# Altium schematic sheet SIM808.SchDoc
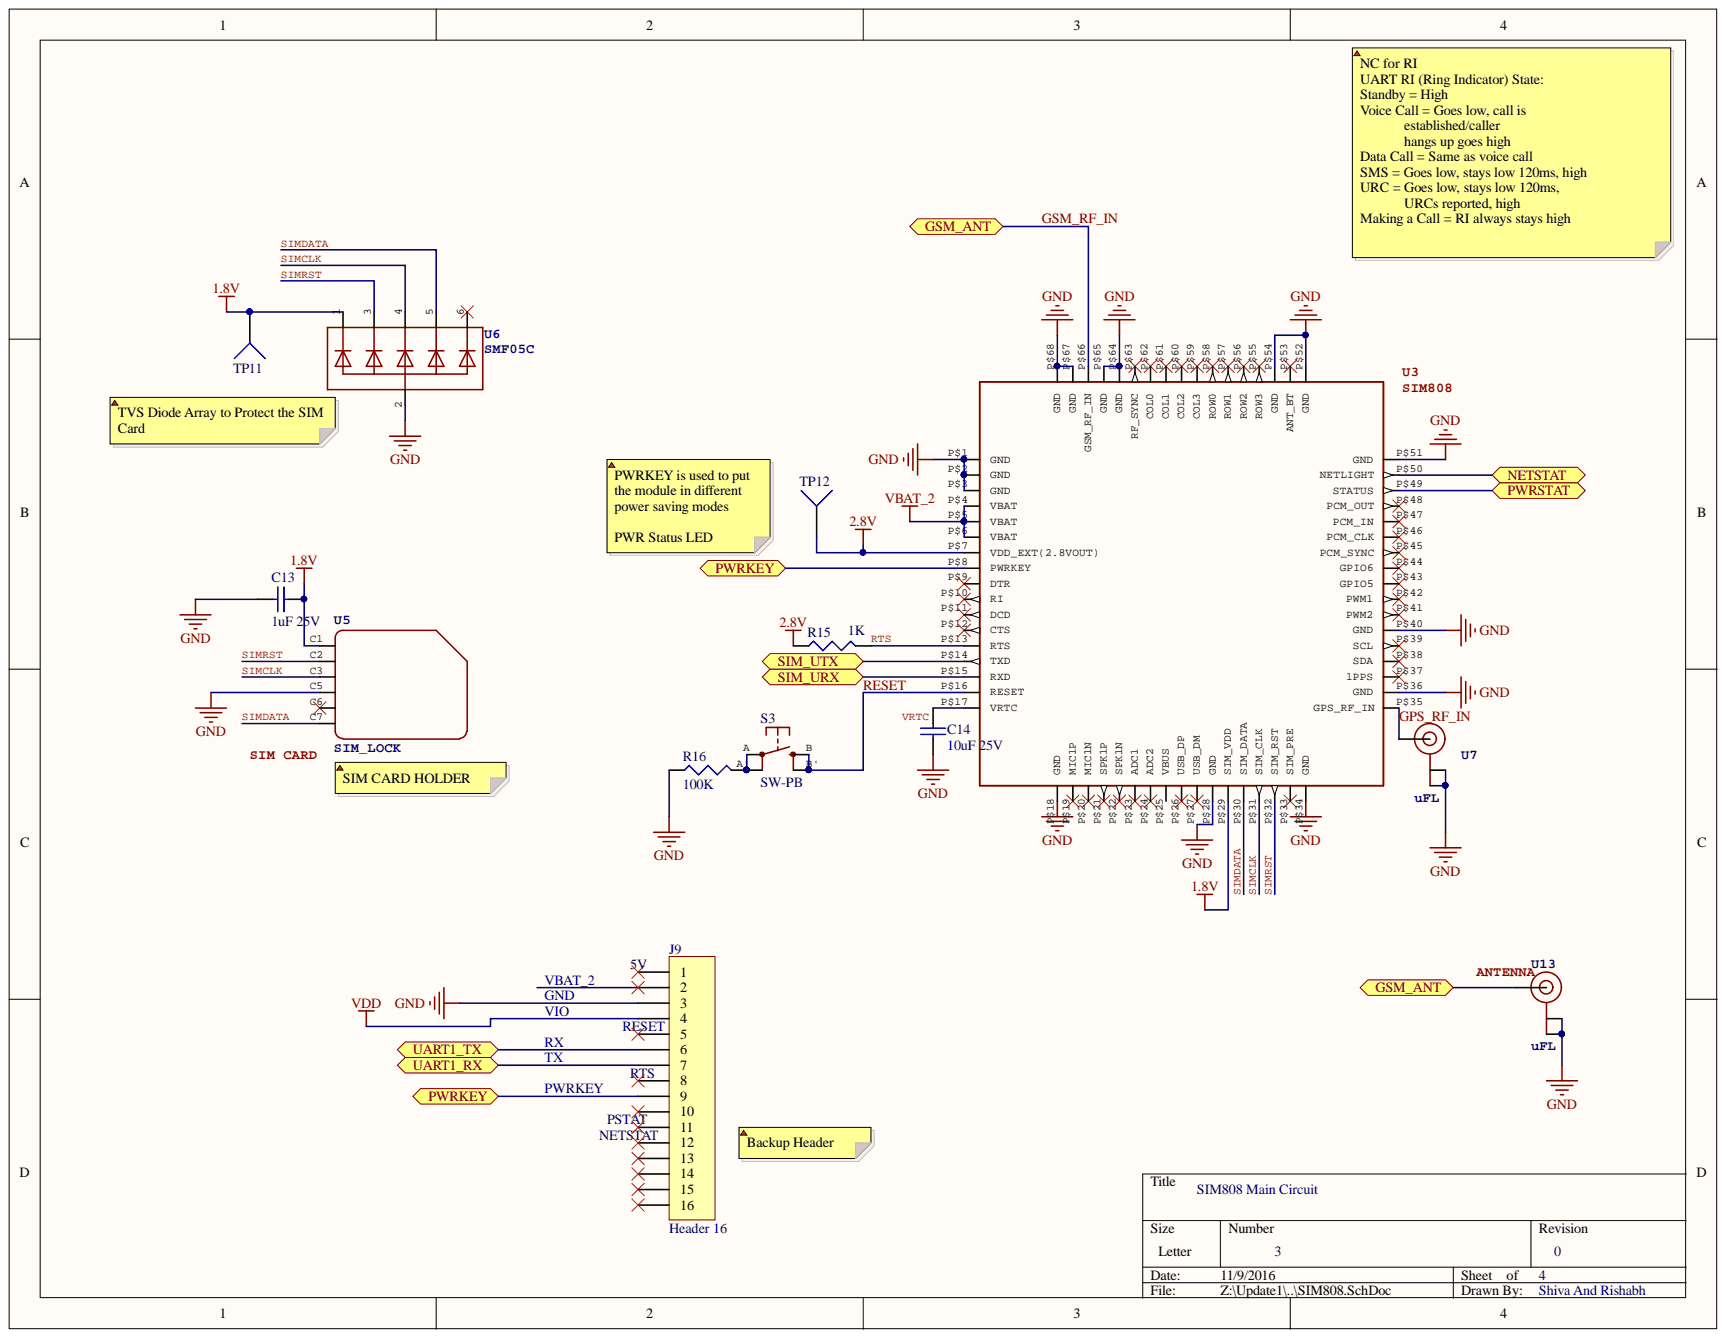
[diagram p0: SIM808.SchDoc]

## Parts
C13  value 1uF 25V  [Cap_1608[0603]]  (hidden comment: Cap1uF)
C14  value 10uF 25V  [Cap_1608[0603]]  (hidden comment: Cap10uF)
J9  Header 16  [HDR1X16]
R15  value 1K  [Res_0603]  (hidden comment: Res1K)
R16  value 100K  [Res_0603]  (hidden comment: Res100K)
S3  SW-PB  [SPST_PB]
TP11  Test Point  [TESTPOINT_1]  (value not drawn)
TP12  Test Point  [TESTPOINT_1]  (value not drawn)
U3  SIM808  [SIM808_SMT]
U5  SIM_LOCK  [SIM_CONN]
U6  SMF05C  [SMF05C_SOT363]
U7  uFL  [U.FL]
U13  uFL  [U.FL]

## Ports
GSM_ANT, NETSTAT, PWRKEY, PWRSTAT, SIM_URX, SIM_UTX, UART1_RX, UART1_TX

## Nets
1.8V: C13.2 TP11.1 U3.P$29(SIM_VDD) U5.C1 U6.1
2.8V: R15.1 TP12.1 U3.P$7(VDD_EXT(2.8VOUT))
GND: C13.1 C14.1 J9.3 R16.1 U3.P$1(GND) U3.P$2(GND) U3.P$3(GND) U3.P$18(GND) U3.P$28(GND) U3.P$34(GND) U3.P$36(GND) U3.P$40(GND) U3.P$51(GND) U3.P$52(GND) U3.P$54(GND) U3.P$64(GND) U3.P$65(GND) U3.P$67(GND) U3.P$68(GND) U5.C5 U6.2 U7.1 U7.2 U13.1 U13.2
VBAT_2: U3.P$4(VBAT) U3.P$5(VBAT) U3.P$6(VBAT)
VDD: J9.4
GPS_RF_IN: U3.P$35(GPS_RF_IN) U7.3
GSM_RF_IN: U3.P$66(GSM_RF_IN) U13.3 ; port GSM_ANT
NETSTAT: U3.P$50(NETLIGHT) ; port NETSTAT
PWRKEY: J9.9 U3.P$8(PWRKEY) ; port PWRKEY
PWRSTAT: U3.P$49(STATUS) ; port PWRSTAT
RESET: S3.B S3.B' U3.P$16(RESET)
RTS: R15.2 U3.P$13(RTS)
SIM_URX: U3.P$15(RXD) ; port SIM_URX
SIM_UTX: U3.P$14(TXD) ; port SIM_UTX
SIMCLK: U3.P$31(SIM_CLK) U5.C3 U6.4
SIMDATA: U3.P$30(SIM_DATA) U5.C7 U6.5
SIMRST: U3.P$32(SIM_RST) U5.C2 U6.3
UART1_RX: J9.7 ; port UART1_RX
UART1_TX: J9.6 ; port UART1_TX
NetC14_2: C14.2 U3.P$17(VRTC)
NetR16_2: R16.2 S3.A S3.A'
VRTC:

Not connected: J9 1 2 5 8 10 11 12 13 14 15 16; U3 P$9(DTR) P$10(RI) P$11(DCD) P$12(CTS) P$19(MIC1P) P$20(MIC1N) P$21(SPK1P) P$22(SPK1N) P$23(ADC1) P$24(ADC2) P$25(VBUS) P$26(USB_DP) P$27(USB_DM) P$33(SIM_PRE) P$37(1PPS) P$38(SDA) P$39(SCL) P$41(PWM2) P$42(PWM1) P$43(GPIO5) P$44(GPIO6) P$45(PCM_SYNC) P$46(PCM_CLK) P$47(PCM_IN) P$48(PCM_OUT) P$53(ANT_BT) P$55(ROW3) P$56(ROW2) P$57(ROW1) P$58(ROW0) P$59(COL3) P$60(COL2) P$61(COL1) P$62(COL0) P$63(RF_SYNC); U5 C6; U6 6

## Library data of the parts
C13 = Cap1uF: Capacitor (Semiconductor SIM Model); Manufacturer: Murata Electronics North America; ManufacturerPartNo: GRM188R61E105KA12D; Component Kind: Standard; ComponentLink1Description: Datasheet; ComponentLink1URL: http://search.murata.co.jp/Ceramy/image/img/A01X/G101/ENG/GRM188R61E105KA12-01.pdf; Library Name: LR_Integrated_Library.IntLib; Supplier: Digikey; SupplierLink1URL: http://www.digikey.com/product-detail/en/murata-electronics-north-america/GRM188R61E105KA12D/490-3897-1-ND/965939; SupplierPartNo: 490-3897-1-ND
C14 = Cap10uF: Capacitor (Semiconductor SIM Model); Manufacturer: Murata Electronics North America; ManufacturerPartNo: GRM188R61E106MA73D; Value: 10uF 25V; Component Kind: Standard; ComponentLink1Description: Datasheet; ComponentLink1URL: http://search.murata.co.jp/Ceramy/image/img/A01X/G101/ENG/GRM188R61E106MA73-01.pdf; Library Name: LR_Integrated_Library.IntLib; Supplier: Digikey; SupplierLink1URL: http://www.digikey.com/product-detail/en/murata-electronics-north-america/GRM319R71H104KA01D/490-1775-1-ND/587528; SupplierPartNo: 490-7202-1-ND
J9 = Header 16: Header, 16-Pin; Manufacturer: 4UCON; ManufacturerPartNo: 17781; ComponenetLink1URL: http://cdn.sparkfun.com/datasheets/Prototyping/17781.pdf; Component Kind: Standard; ComponentLink1Description: Drawing/Datasheet; Library Name: LR_Integrated_Library.IntLib; Supplier: Sparkfun; SupplierLink1URL: https://www.sparkfun.com/products/12693; SupplierPartNo: 12693
R15 = Res1K: Resistor; Manufacturer: Yageo; ManufacturerPartNo: AC0603FR-071KL; Component Kind: Standard; ComponentLink1Description: Datasheet; ComponentLink1URL: http://www.yageo.com/NewPortal/yageodocoutput?fileName=/pdf/R-Chip/PYu-AC_51_RoHS_L_5.pdf; Library Name: LR_Integrated_Library.IntLib; Supplier: Digikey; SupplierLink1URL: http://www.digikey.com/product-search/en?keywords=311-1KLDCT-ND; SupplierPartNo: 311-1KLDCT-ND
R16 = Res100K: Resistor; Manufacturer: Yageo; ManufacturerPartNo: AC0603FR-07100KL; Component Kind: Standard; ComponentLink1Description: Datasheet; ComponentLink1URL: http://www.yageo.com/documents/recent/PYu-AC_51_RoHS_L%205.pdf; Library Name: LR_Integrated_Library.IntLib; Supplier: Digikey; SupplierLink1URL: http://www.digikey.com/product-detail/en/yageo/AC0603FR-07100KL/311-100KLDCT-ND/2828134; SupplierPartNo: 311-100KLDCT-ND
S3 = SW-PB: Tactile Switch SPST-NO Top Actuated Surface Mount; Manufacturer: C&K Components; ManufacturerPartNo: KMR211GLFS; Component Kind: Standard; ComponentLink1Description: Drawing/Datasheet; ComponentLink1URL: http://www.ckswitches.com/media/1479/kmr2.pdf; Library Name: LR_Integrated_Library.IntLib; Supplier: Digikey; SupplierLink1URL: http://www.digikey.com/product-search/en?keywords=401-1426-1-ND%20; SupplierPartNo: 401-1426-1-ND
U3 = GSM_SIM808: SIM808 GSM Module; Manufacturer: SIM; Component Kind: Standard; ComponentLink1Description: Datasheet; ComponentLink1URL: https://cdn-shop.adafruit.com/product-files/2637/SIM808_Hardware+Design_V1.02.pdf; Library Name: LR_Integrated_Library.IntLib; ManufacturerPartNo: SIM808; Supplier: Adafruit; SupplierLink1URL: https://www.adafruit.com/products/2637; SupplierPartNo: 2637
U5 = SIM_LOCK: C707A SIMLOCK® 2.5 mm with wide solder tails   Source: Data sheet; Manufacturer: Amphenol FCI; ManufacturerPartNo: 7111S2015X02LF; Component Kind: Standard; ComponentLink1Description: Datasheet; ComponentLink1URL: http://portal.fciconnect.com/Comergent//fci/drawing/vjw54355.pdf; Library Name: LR_Integrated_Library.IntLib; Supplier: Adafruit; Supplier2: Digikey; Supplier2PartNo: 609-1401-1-ND; SupplierLink1URL: https://www.adafruit.com/products/2630; SupplierLink2URL: http://www.digikey.com/product-detail/en/amphenol-fci/7111S2015X02LF/609-1401-1-ND/1002961; SupplierPartNo: 2630
U6 = SMF05C: Voltage Transient Suppressor Array   SOT363 SMF05C - 100W 5V: Digikey - SMF05CT1GOSCT-ND; Manufacturer: ON Semiconductor; Component Kind: Standard; ComponentLink1Description: Datasheet; ComponentLink1URL: http://www.onsemi.com/pub_link/Collateral/SMF05C-D.PDF; Library Name: LR_Integrated_Library.IntLib; ManufacturerPartNo: SMF05CT1G; Supplier: Digikey; SupplierLink1URL: http://www.digikey.com/product-search/en?keywords=SMF05CT1GOSCT-ND; SupplierPartNo: SMF05CT1GOSCT-ND
U7 U13 = ANTENNA_U.FL: U.FL Antenna Connector; Manufacturer: Molex Incorporated; ManufacturerPartNo: 73412-0110; Component Kind: Standard; ComponentLink1Description: Datasheet; ComponentLink1URL: https://cdn-shop.adafruit.com/datasheets/734120114_sd.pdf; Library Name: LR_Integrated_Library.IntLib; Supplier: Adafruit; SupplierLink1URL: https://www.adafruit.com/product/1661; SupplierPartNo: 1661
TP11 TP12 = Test Point: Component Kind: Standard; Description: Test Point; LatestRevisionDate: 17-Jul-2002; LatestRevisionNote: Re-released for DXP Platform.; Library Name: LR_Integrated_Library.IntLib; Publisher: Altium Limited
